# Altium schematic sheet DIY Teensy 3.6 - Rev 6a.SchDoc("DIY Teensy 3.6 - Rev 6a")
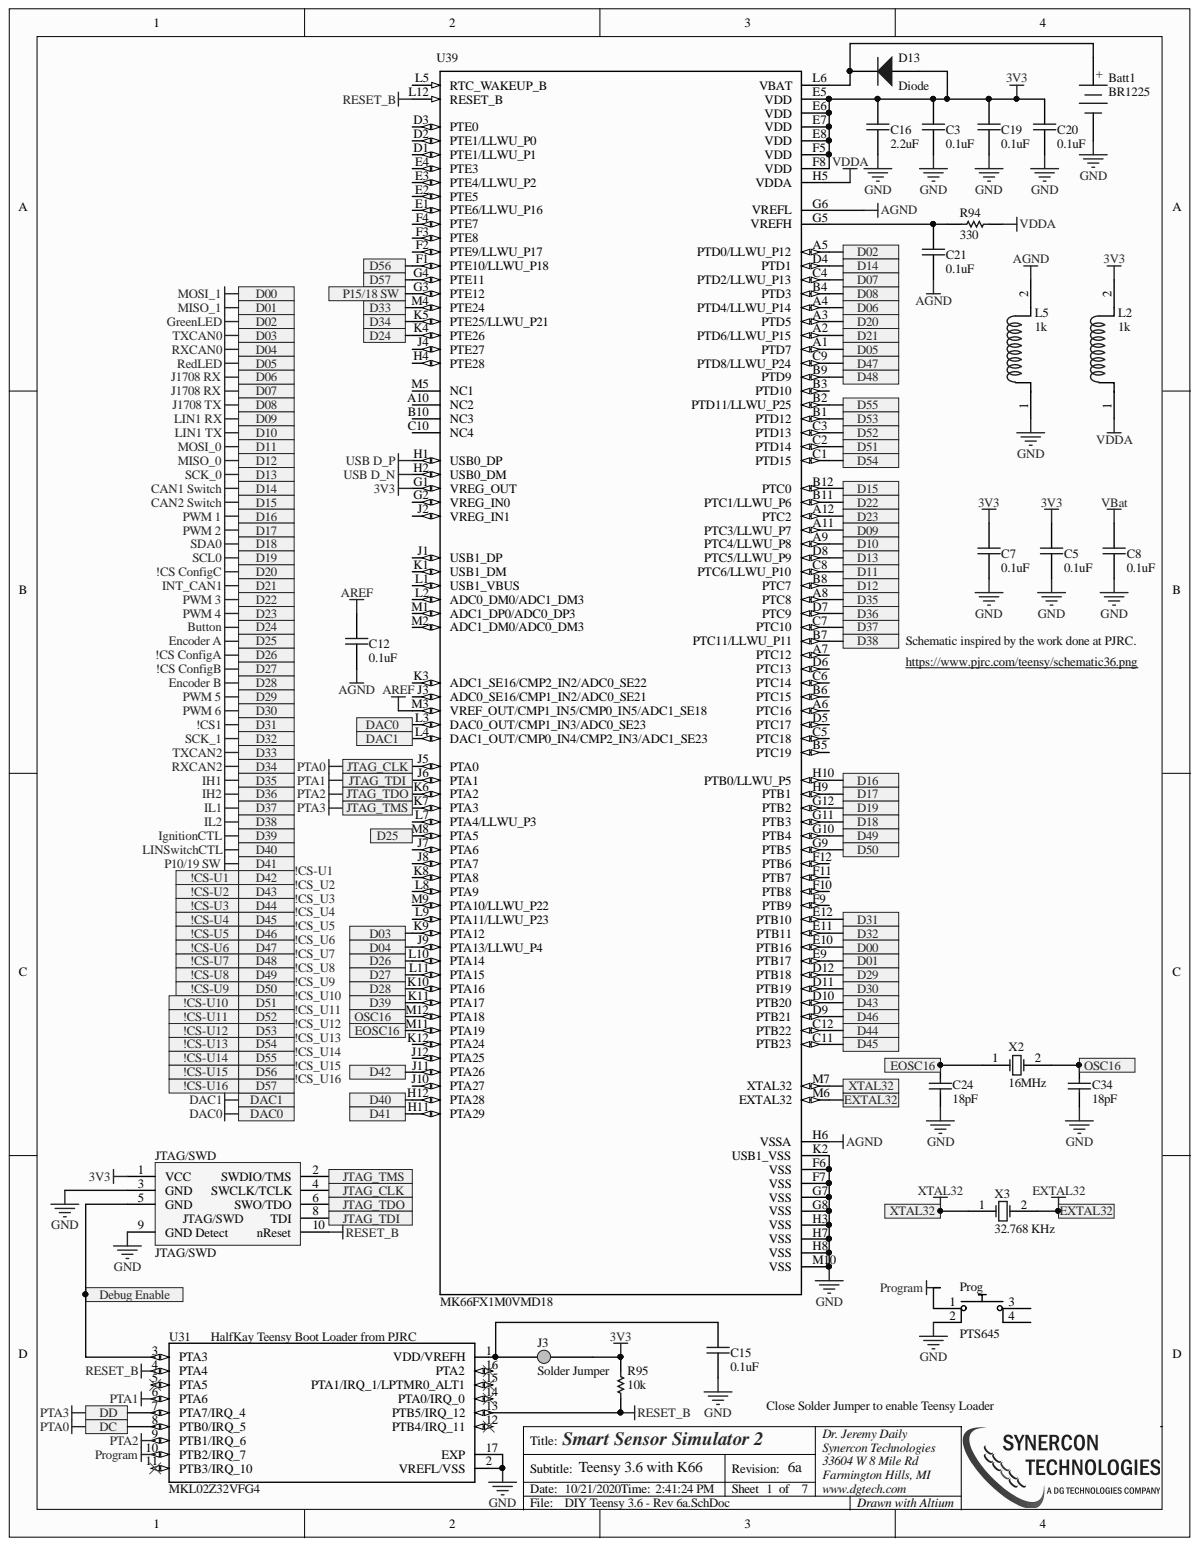
[diagram p0: DIY Teensy 3.6 - Rev 6a.SchDoc]

## Parts
Batt1  BR1225  [Coin Cell BR-1225/VCN]
C3  0.1uF  [0603]
C5  0.1uF  [0603]
C7  0.1uF  [0603]
C8  0.1uF  [0603]
C12  0.1uF  [0603]
C15  0.1uF  [0603]
C16  2.2uF  [0603]
C19  0.1uF  [0603]
C20  0.1uF  [0603]
C21  0.1uF  [0603]
C24  18pF  [0603]
C34  18pF  [0603]
D13  Diode  [CST2C]
J3  Solder Jumper  [Solder Jumper]
JTAG/SWD  JTAG/SWD  [JTAG 10-pin Header]
L2  1k  [0603]
L5  1k  [0603]
Prog  PTS645  [Vertical Tactile Switch]
R94  330  [0603]
R95  10k  [0603]
U31  MKL02Z32VFG4  Supplier 1: PJRC  [*QFN50P300X300X65-17N]
U39  MK66FX1M0VMD18  [*BGA144C100P12X12_1300X1300X170_MK66FX1M0VMD18(Primary)]
X2  16MHz  [XTAL 2 pad]
X3  32.768 KHz  [XTAL 2-pad Small]

## Ports
!CS-U1, !CS-U2, !CS-U3, !CS-U4, !CS-U5, !CS-U6, !CS-U7, !CS-U8, !CS-U9, !CS-U10, !CS-U11, !CS-U12, !CS-U13, !CS-U14, !CS-U15, !CS-U16, D00, D01, D02, D03, D04, D05, D06, D07, D08, D09, D10, D11, D12, D13, D14, D15, D16, D17, D18, D19, D20, D21, D22, D23, D24, D25, D26, D27, D28, D29, D30, D31, D32, D33, D34, D35, D36, D37, D38, D39, D40, D41, D42, D43, D44, D45, D46, D47, D48, D49, D50, D51, D52, D53, D54, D55, D56, D57, DAC0, DAC1, DC, DD, Debug Enable, EOSC16, EXTAL32, JTAG_CLK, JTAG_TDI, JTAG_TDO, JTAG_TMS, OSC16, P15/18 SW, XTAL32

## Nets
3V3: C3.2 C5.2 C7.2 C16.2 C19.2 C20.2 D13.1 J3.2 JTAG/SWD.1(VCC) L2.2 R95.1 U39.E5(VDD) U39.E6(VDD) U39.E7(VDD) U39.E8(VDD) U39.F5(VDD) U39.F8(VDD) U39.G1(VREG_OUT)
AGND: C12.1 C21.1 L5.2 U39.G6(VREFL) U39.H6(VSSA)
AREF: C12.2 U39.M3(VREF_OUT/CMP1_IN5/CMP0_IN5/ADC1_SE18)
GND: Batt1.2 C3.1 C5.1 C7.1 C8.1 C15.1 C16.1 C19.1 C20.1 C24.1 C34.1 JTAG/SWD.3(GND) JTAG/SWD.9(GND_Detect) L5.1 Prog.2 U31.2(VREFL/VSS) U31.17(EXP) U39.F6(VSS) U39.F7(VSS) U39.G7(VSS) U39.G8(VSS) U39.H3(VSS) U39.H7(VSS) U39.H8(VSS) U39.K2(USB1_VSS) U39.M10(VSS)
Program: Prog.1 U31.10(PTB2/IRQ_7)
RESET_B: JTAG/SWD.10(nReset) R95.2 U31.4(PTA4) U31.13(PTB5/IRQ_12) U39.L12(RESET_B)
USB D_N: U39.H2(USB0_DM)
USB D_P: U39.H1(USB0_DP)
VBat: C8.2
VDDA: L2.1 R94.1 U39.H5(VDDA)
!CS1: U39.E12(PTB10) ; port D31
!CS ConfigA: U39.L10(PTA14) ; port D26
!CS ConfigB: U39.L11(PTA15) ; port D27
!CS ConfigC: U39.A3(PTD5) ; port D20
!CS-U1: U39.J11(PTA26) ; port !CS-U1, port D42
!CS_U2: U39.D10(PTB20) ; port !CS-U2, port D43
!CS_U3: U39.C12(PTB22) ; port !CS-U3, port D44
!CS_U4: U39.C11(PTB23) ; port !CS-U4, port D45
!CS_U5: U39.D9(PTB21) ; port !CS-U5, port D46
!CS_U6: U39.C9(PTD8/LLWU_P24) ; port !CS-U6, port D47
!CS_U7: U39.B9(PTD9) ; port !CS-U7, port D48
!CS_U8: U39.G10(PTB4) ; port !CS-U8, port D49
!CS_U9: U39.G9(PTB5) ; port !CS-U9, port D50
!CS_U10: U39.C2(PTD14) ; port !CS-U10, port D51
!CS_U11: U39.C3(PTD13) ; port !CS-U11, port D52
!CS_U12: U39.B1(PTD12) ; port !CS-U12, port D53
!CS_U13: U39.C1(PTD15) ; port !CS-U13, port D54
!CS_U14: U39.B2(PTD11/LLWU_P25) ; port !CS-U14, port D55
!CS_U15: U39.F1(PTE10/LLWU_P18) ; port !CS-U15, port D56
!CS_U16: U39.G4(PTE11) ; port !CS-U16, port D57
Button: U39.K4(PTE26) ; port D24
CAN1 Switch: U39.D4(PTD1) ; port D14
CAN2 Switch: U39.B12(PTC0) ; port D15
DAC0: U39.L3(DAC0_OUT/CMP1_IN3/ADC0_SE23) ; port DAC0
DAC1: U39.L4(DAC1_OUT/CMP0_IN4/CMP2_IN3/ADC1_SE23) ; port DAC1
Debug Enable: JTAG/SWD.5(GND) U31.3(PTA3) ; port Debug Enable
Encoder A: U39.M8(PTA5) ; port D25
Encoder B: U39.K10(PTA16) ; port D28
EOSC16: C24.2 U39.M11(PTA19) X2.1 ; port EOSC16
EXTAL32: U39.M6(EXTAL32) X3.2 ; port EXTAL32
GreenLED: U39.A5(PTD0/LLWU_P12) ; port D02
IgnitionCTL: U39.K11(PTA17) ; port D39
IH1: U39.A8(PTC8) ; port D35
IH2: U39.D7(PTC9) ; port D36
IL1: U39.C7(PTC10) ; port D37
IL2: U39.B7(PTC11/LLWU_P11) ; port D38
INT_CAN1: U39.A2(PTD6/LLWU_P15) ; port D21
J1708 RX: U39.A4(PTD4/LLWU_P14) U39.C4(PTD2/LLWU_P13) ; port D06, port D07
J1708 TX: U39.B4(PTD3) ; port D08
LIN1 RX: U39.A11(PTC3/LLWU_P7) ; port D09
LIN1 TX: U39.A9(PTC4/LLWU_P8) ; port D10
LINSwitchCTL: U39.H12(PTA28) ; port D40
MISO_0: U39.B8(PTC7) ; port D12
MISO_1: U39.E9(PTB17) ; port D01
MOSI_0: U39.C8(PTC6/LLWU_P10) ; port D11
MOSI_1: U39.E10(PTB16) ; port D00
OSC16: C34.2 U39.M12(PTA18) X2.2 ; port OSC16
P10/19 SW: U39.H11(PTA29) ; port D41
P15/18 SW: U39.G3(PTE12) ; port P15/18 SW
PTA0: JTAG/SWD.4(SWCLK/TCLK) U31.8(PTB0/IRQ_5) U39.J5(PTA0) ; port DC, port JTAG_CLK
PTA1: JTAG/SWD.8(TDI) U31.6(PTA6) U39.J6(PTA1) ; port JTAG_TDI
PTA2: JTAG/SWD.6(SWO/TDO) U31.9(PTB1/IRQ_6) U39.K6(PTA2) ; port JTAG_TDO
PTA3: JTAG/SWD.2(SWDIO/TMS) U31.7(PTA7/IRQ_4) U39.K7(PTA3) ; port DD, port JTAG_TMS
PWM 1: U39.H10(PTB0/LLWU_P5) ; port D16
PWM 2: U39.H9(PTB1) ; port D17
PWM 3: U39.B11(PTC1/LLWU_P6) ; port D22
PWM 4: U39.A12(PTC2) ; port D23
PWM 5: U39.D12(PTB18) ; port D29
PWM 6: U39.D11(PTB19) ; port D30
RedLED: U39.A1(PTD7) ; port D05
RXCAN0: U39.J9(PTA13/LLWU_P4) ; port D04
RXCAN2: U39.K5(PTE25/LLWU_P21) ; port D34
SCK_0: U39.D8(PTC5/LLWU_P9) ; port D13
SCK_1: U39.E11(PTB11) ; port D32
SCL0: U39.G12(PTB2) ; port D19
SDA0: U39.G11(PTB3) ; port D18
TXCAN0: U39.K9(PTA12) ; port D03
TXCAN2: U39.M4(PTE24) ; port D33
XTAL32: U39.M7(XTAL32) X3.1 ; port XTAL32
NetBatt1_1: Batt1.1 D13.2 U39.L6(VBAT)
NetC15_2: C15.2 J3.1 U31.1(VDD/VREFH)
NetC21_2: C21.2 R94.2 U39.G5(VREFH)

Not connected: Prog 3 4; U31 5(PTA5) 11(PTB3/IRQ_10) 12(PTB4/IRQ_11) 14(PTA0/IRQ_0) 15(PTA1/IRQ_1/LPTMR0_ALT1) 16(PTA2); U39 A6(PTC16) A7(PTC12) A10(NC2) B3(PTD10) B5(PTC19) B6(PTC15) B10(NC3) C5(PTC18) C6(PTC14) C10(NC4) D1(PTE1/LLWU_P1) D2(PTE1/LLWU_P0) D3(PTE0) D5(PTC17) D6(PTC13) E1(PTE6/LLWU_P16) E2(PTE5) E3(PTE4/LLWU_P2) E4(PTE3) F2(PTE9/LLWU_P17) F3(PTE8) F4(PTE7) F9(PTB9) F10(PTB8) F11(PTB7) F12(PTB6) G2(VREG_IN0) H4(PTE28) J1(USB1_DP) J2(VREG_IN1) J3(ADC0_SE16/CMP1_IN2/ADC0_SE21) J4(PTE27) J7(PTA6) J8(PTA7) J10(PTA27) J12(PTA25) K1(USB1_DM) K3(ADC1_SE16/CMP2_IN2/ADC0_SE22) K8(PTA8) K12(PTA24) L1(USB1_VBUS) L2(ADC0_DM0/ADC1_DM3) L5(RTC_WAKEUP_B) L7(PTA4/LLWU_P3) L8(PTA9) L9(PTA11/LLWU_P23) M1(ADC1_DP0/ADC0_DP3) M2(ADC1_DM0/ADC0_DM3) M5(NC1) M9(PTA10/LLWU_P22)

## Library data of the parts
Batt1 = CR2032 Battery Holder: Lithium Battery Non-Rechargeable (Primary) 3V Coin, 12.5mm; PartNumber: BR-1225/VCN; Manufacturer: Panasonic; Supplier Part Number 1: P192-ND; Supplier 1: Digi-Key; Library Name: SSS Schematic Library.SchLib; Component Kind: Standard
C3 C5 C7 C8 C12 C15 C19-C21 = Cap: CAP CER 0.1UF 50V X7R 0603; PartNumber: GRM188R72A104KA35D; Manufacturer: Murata Electronics; Alternative: Acceptable; Supplier Part Number 1: 490-3285-1-ND; Supplier 1: Digi-Key; Library Name: SSS Integrated Library.IntLib; Component Kind: Standard
C16 = Cap: 2.2µF ±10% 6.3V Ceramic Capacitor X5R 0603 (1608 Metric); PartNumber: JMK107BJ225KAHT; Manufacturer: Taiyo Yuden; Alternative: Acceptable; Supplier Part Number 1: 587-3386-1-ND; Supplier 1: Digi-Key; Library Name: SSS Integrated Library.IntLib; Component Kind: Standard
C24 C34 = Cap: CAP CER 18PF 50V 5% NP0 0603; PartNumber: C0603C180J5GACTU; Manufacturer: Kemet; Supplier Part Number 1: 399-1052-1-ND; Supplier 1: Digi-Key; Simulation: CAP; Signal Integrity: Cap; Library Name: SSS Schematic Library.SchLib; Component Kind: Standard
D13 = Diode: Default Diode; PartNumber: CCS15S30L3FCT-ND; Manufacturer: Toshiba Semiconductor and Storage; Supplier Part Number 1: CCS15S30L3FCT-ND; Supplier 1: Digi-Key; Simulation: DIODE; Signal Integrity: Diode; Library Name: Miscellaneous Devices.IntLib; Component Kind: Standard
JTAG/SWD = JTAG Cortex: Connector Header Surface Mount 10 position 0.050" (1.27mm); PartNumber: 3221-10-0300-00; Manufacturer: CNC Tech; Supplier Part Number 1: 1175-1735-ND; Supplier 1: Digi-Key; Library Name: Custom Parts Schematics.SchLib; Component Kind: Standard
L2 L5 = Ferrite Bead: Ferrite Beads Multi-Layer Power 1KOhm 25% 100MHz 1A 200mOhm DCR 0603 Automotive T/R; PartNumber: ERJ-3EKF1001V; Manufacturer: Panasonic Electronic Components; Alternative: Acceptable; Supplier Part Number 1: P1.00KHCT-ND; Supplier 1: Digi-Key; Library Name: SSS Integrated Library.IntLib; Component Kind: Standard
Prog = Switch - Tactile_2: Tactile Switch SPST-NO Side Actuated Through Hole, Right Angle; PartNumber: PTS645VL31-2 LFS; Manufacturer: C & K Components; Supplier Part Number 1: CKN9094-ND; Supplier 1: Digi-Key; Library Name: Custom Parts Schematics.SchLib; Component Kind: Standard
R94 = Chip Resistor: 330 Ohms ±1% 0.1W, 1/10W Chip Resistor 0603 (1608 Metric) Automotive AEC-Q200, High Voltage Thick Film; PartNumber: KTR03EZPF3300; Manufacturer: Rohm Semiconductor; Alternative: Acceptable; Supplier Part Number 1: RHM330AYCT-ND; Supplier 1: Digi-Key; Library Name: SSS Integrated Library.IntLib; Component Kind: Standard
R95 = Chip Resistor: 10 kOhms ±1% 0.1W, 1/10W Chip Resistor 0603 (1608 Metric) Automotive AEC-Q200, High Voltage Thick Film; PartNumber: KTR03EZPF1002; Manufacturer: Rohm Semiconductor; Alternative: Acceptable; Supplier Part Number 1: RHM10.0KAYCT-ND; Supplier 1: Digi-Key; Library Name: SSS Integrated Library.IntLib; Component Kind: Standard
U31 = MKL02Z32VFG4: 32 Bit Microcontroller, Kinetis L Series Ultra Low Power, ARM Cortex-M0+, 48 MHz, 32 KB, 4 KB, 16; PartNumber: Pre Programmed MKL02Z32VFG4; Alternative: Sole Source; Supplier Part Number 1: IC_MKL02Z32_QFN16; Manufacturer: PJRC; Library Name: MKL02Z32VFG4.IntLib; Component Kind: Standard
U39 = MK66FX1M0VMD18: Kinetis K66: 180MHz Cortex-M4F MCU, 1.2MB Flash, 256KB SRAM, 2xUSB, Ethernet, 144-MAPBGA; Manufacturer: NXP USA Inc.; Supplier Part Number 1: 568-13335-ND; Supplier 1: Digi-Key; PartNumber: MK66FX1M0VMD18; Library Name: MK66FX1M0VMD18.IntLib; Component Kind: Standard
X2 = Crystal Oscillator: CRYSTAL 16MHZ 18PF SMD; PartNumber: 7A-16.000MAAJ-T; Manufacturer: TXC CORPORATION; Supplier Part Number 1: 887-1086-1-ND; Supplier 1: Digi-Key; Library Name: SSS Schematic Library.SchLib; Component Kind: Standard
X3 = Crystal Oscillator: Canned Oscillator; PartNumber: ECX-.327-CDX-1293; Manufacturer: ECS Inc.; Supplier Part Number 1: XC2292CT-ND; Supplier 1: Digi-Key; Library Name: SSS Integrated Library.IntLib; Component Kind: Standard
J3 = Solder Jumper: Library Name: SSS Integrated Library.IntLib; Component Kind: Standard
